# Altium schematic sheet INTERFACES.SchDoc
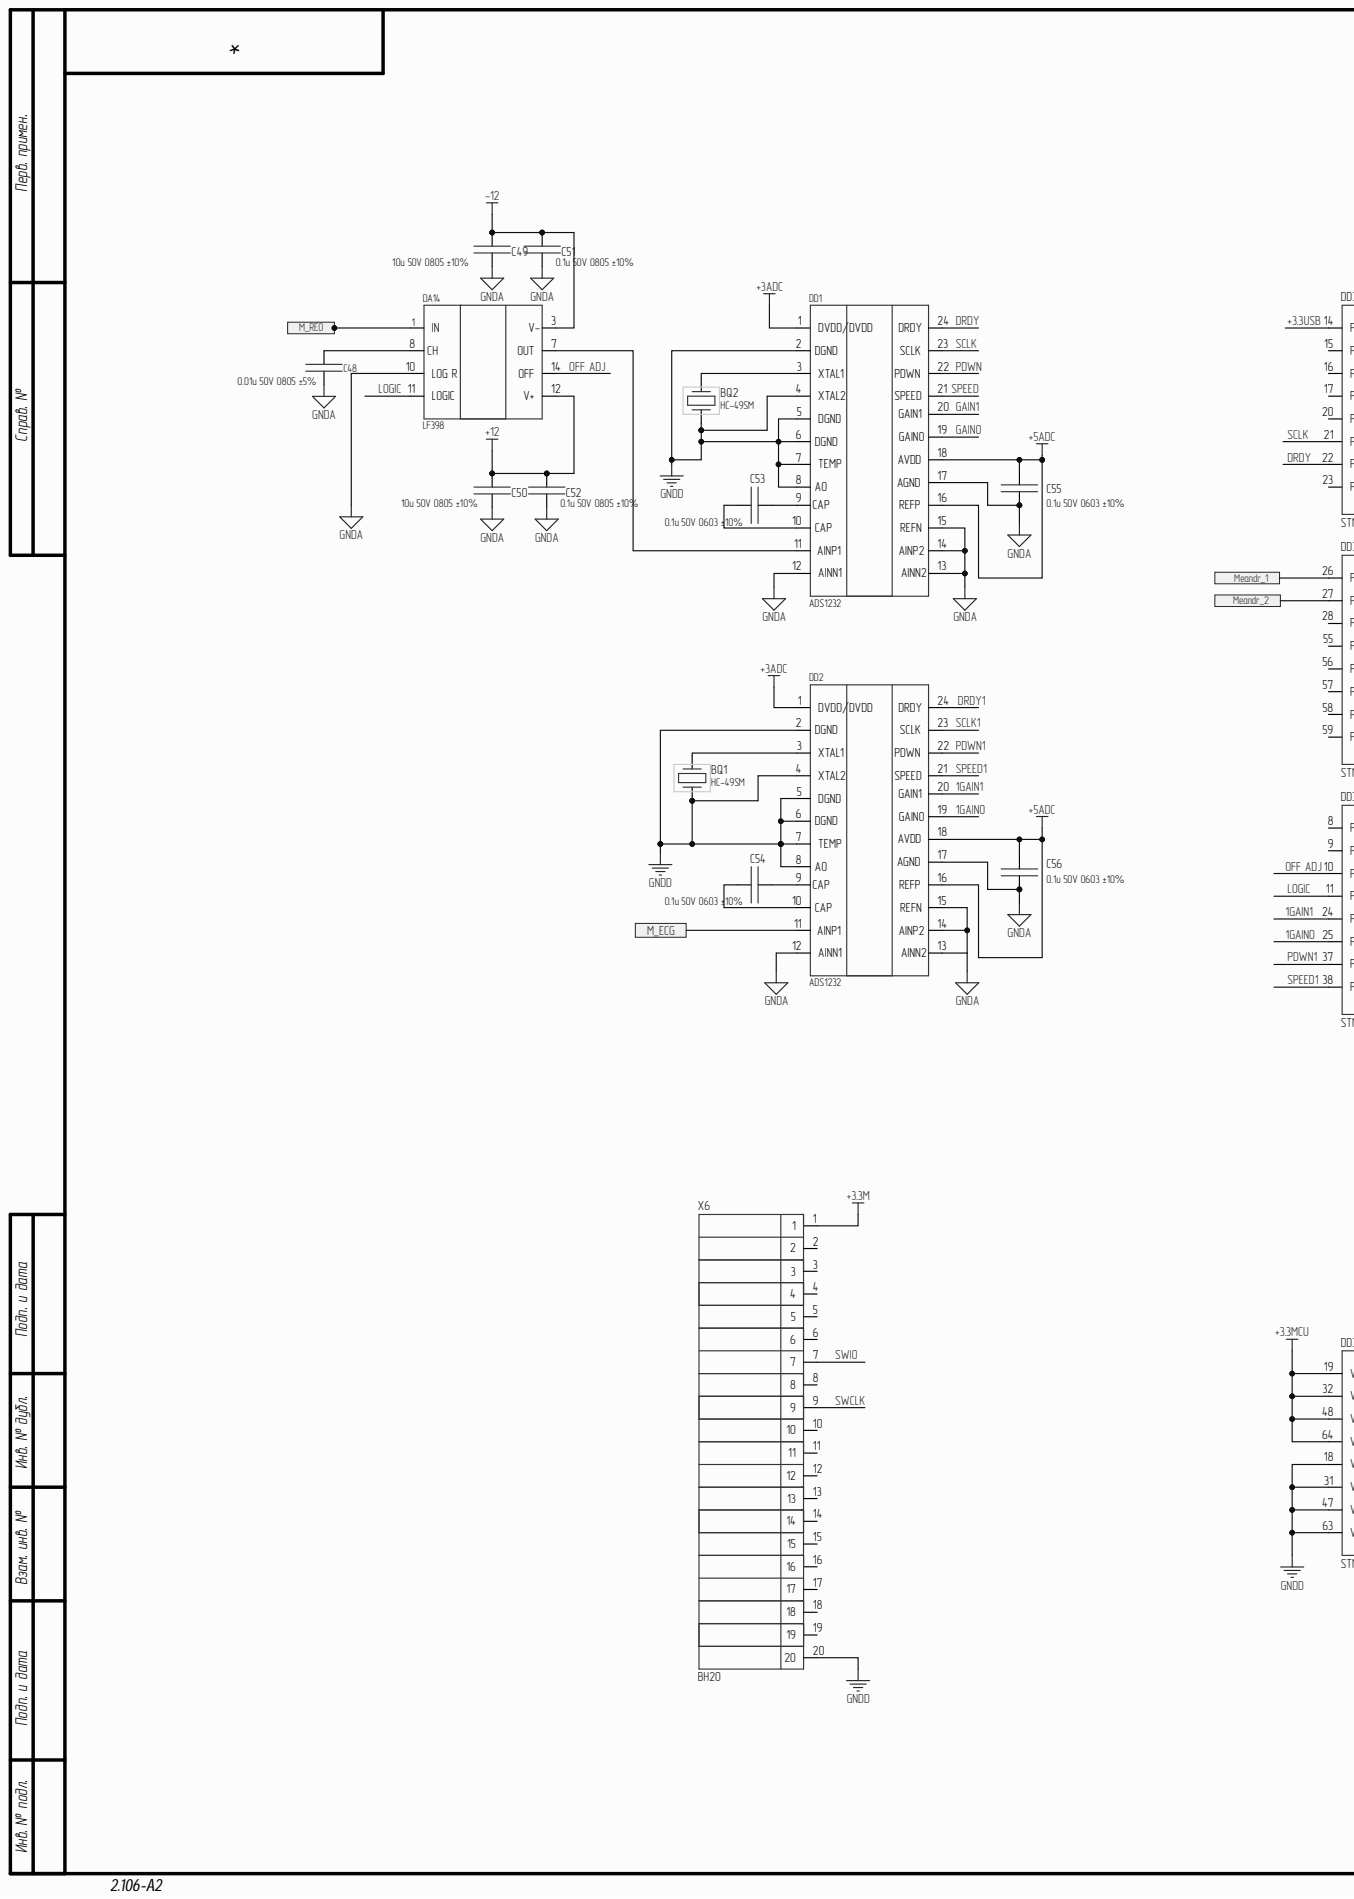
[diagram p0: INTERFACES.SchDoc, left]
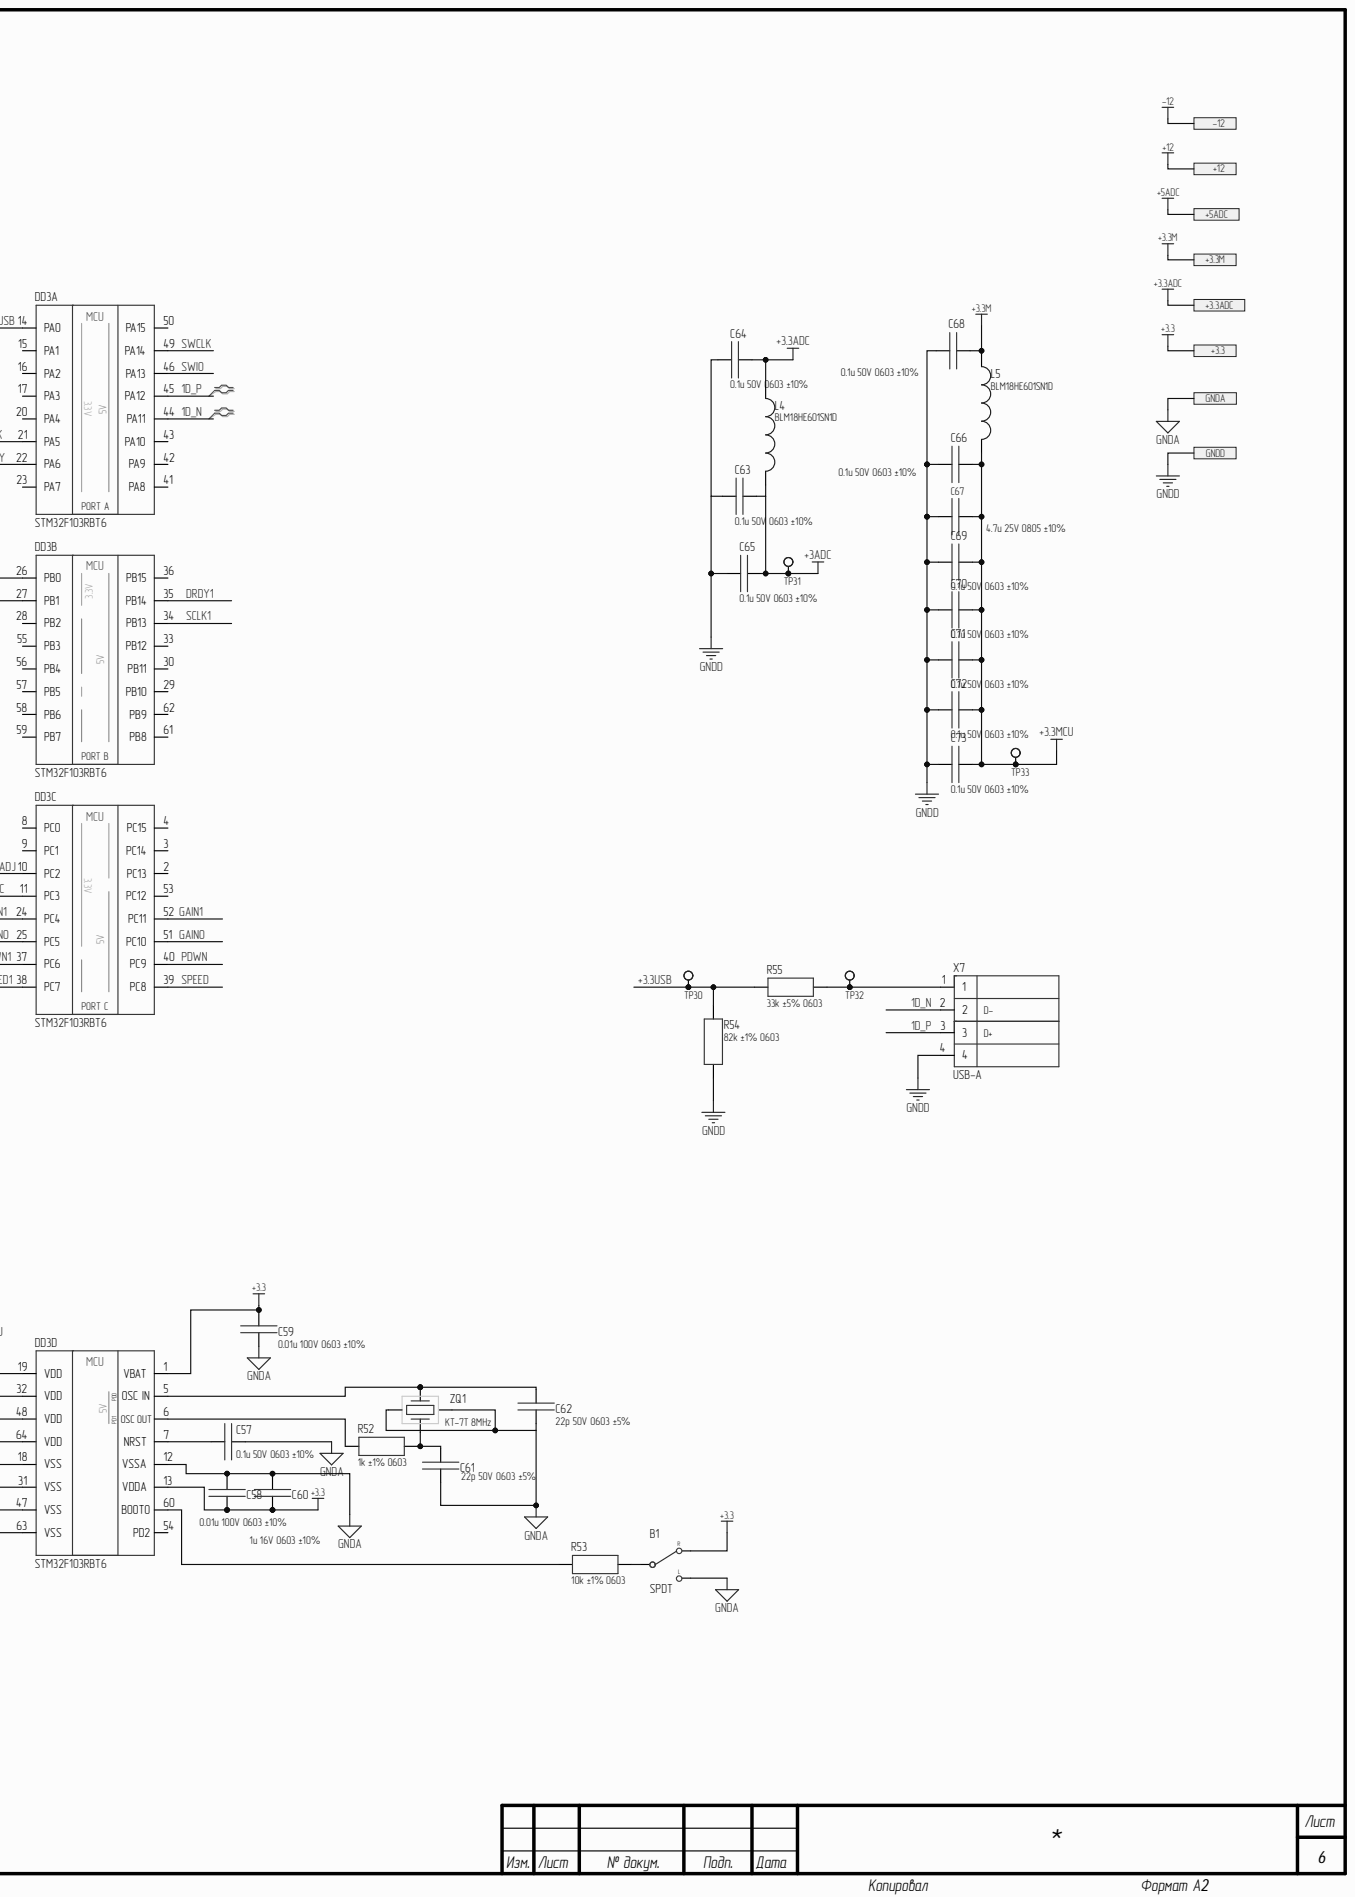
[diagram p1: INTERFACES.SchDoc, right]

## Parts
B1  SPDT  [Slide Switch 3 pin]
BQ1  HC-49SM  [CRYSTAL: HC-49SM]
BQ2  HC-49SM  [CRYSTAL: HC-49SM]
C48  0.01u 50V 0805 ±5%  [CAP: SMD 0805 MD IPC 782]
C49  10u 50V 0805 ±10%  [CAP: SMD 0805 MD IPC 782]
C50  10u 50V 0805 ±10%  [CAP: SMD 0805 MD IPC 782]
C51  0.1u 50V 0805 ±10%  [CAP: SMD 0805 MD IPC 782]
C52  0.1u 50V 0805 ±10%  [CAP: SMD 0805 MD IPC 782]
C53  0.1u 50V 0603 ±10%  [CAP: SMD 0603 MD IPC 782]
C54  0.1u 50V 0603 ±10%  [CAP: SMD 0603 MD IPC 782]
C55  0.1u 50V 0603 ±10%  [CAP: SMD 0603 MD IPC 782]
C56  0.1u 50V 0603 ±10%  [CAP: SMD 0603 MD IPC 782]
C57  0.1u 50V 0603 ±10%  [CAP: SMD 0603 MD IPC 782]
C58  0.01u 100V 0603 ±10%  [CAP: SMD 0603 MD IPC 782]
C59  0.01u 100V 0603 ±10%  [CAP: SMD 0603 MD IPC 782]
C60  1u 16V 0603 ±10%  [CAP: SMD 0603 MD IPC 782]
C61  22p 50V 0603 ±5%  [CAP: SMD 0603 MD IPC 782]
C62  22p 50V 0603 ±5%  [CAP: SMD 0603 MD IPC 782]
C63  0.1u 50V 0603 ±10%  [CAP: SMD 0603 MD IPC 782]
C64  0.1u 50V 0603 ±10%  [CAP: SMD 0603 MD IPC 782]
C65  0.1u 50V 0603 ±10%  [CAP: SMD 0603 MD IPC 782]
C66  0.1u 50V 0603 ±10%  [CAP: SMD 0603 MD IPC 782]
C67  4.7u 25V 0805 ±10%  [CAP: SMD 0805 MD IPC 782]
C68  0.1u 50V 0603 ±10%  [CAP: SMD 0603 MD IPC 782]
C69  0.1u 50V 0603 ±10%  [CAP: SMD 0603 MD IPC 782]
C70  0.1u 50V 0603 ±10%  [CAP: SMD 0603 MD IPC 782]
C71  0.1u 50V 0603 ±10%  [CAP: SMD 0603 MD IPC 782]
C72  0.1u 50V 0603 ±10%  [CAP: SMD 0603 MD IPC 782]
C73  0.1u 50V 0603 ±10%  [CAP: SMD 0603 MD IPC 782]
DA14  LF398  [IC: SOIC 14]
DD1  ADS1232  [TSSOP-24]
DD2  ADS1232  [TSSOP-24]
DD3  STM32F103RBT6  [MC: LQFP-64]  (parts A,B,C,D)
L4  BLM18HE601SN1D  [IND: SMD 0603 MD IPC 782]
L5  BLM18HE601SN1D  [IND: SMD 0603 MD IPC 782]
R52  1k ±1% 0603  [RES: SMD 0603 MD IPC 782]
R53  10k ±1% 0603  [RES: SMD 0603 MD IPC 782]
R54  82k ±1% 0603  [RES: SMD 0603 MD IPC 782]
R55  33k ±5% 0603  [RES: SMD 0603 MD IPC 782]
TP30  Test point  [Test Point]  (value not drawn)  (net tie)
TP31  Test point  [Test Point]  (value not drawn)  (net tie)
TP32  Test point  [Test Point]  (value not drawn)  (net tie)
TP33  Test point  [Test Point]  (value not drawn)  (net tie)
X6  BH20  [CON: BH-20]
X7  USB-A  [CON: 4PIN USB B]
ZQ1  KT-7T 8MHz  [CRYSTAL: 3225 4pin]

## Ports
+3.3, +3.3ADC, +3.3M, +5ADC, +12, -12, GNDA, GNDD, M_ECG, M_REO, Meandr_1, Meandr_2

## Nets
+3.3MCU: C66.1 C67.1 C69.2 C70.2 C71.2 C72.2 C73.2 DD3.19(VDD) DD3.32(VDD) DD3.48(VDD) DD3.64(VDD) L5.2 TP33.1
+3ADC: C63.1 C65.2 DD1.1(DVDD/DVDD) DD2.1(DVDD/DVDD) L4.2 TP31.1
1D_N: DD3.44(PA11) X7.2
1D_P: DD3.45(PA12) X7.3
1GAIN0: DD2.19(GAIN0) DD3.25(PC5)
1GAIN1: DD2.20(GAIN1) DD3.24(PC4)
+3.3: B1.3 C58.2 C59.1 C60.2 DD3.1(VBAT) DD3.13(VDDA) ; port +3.3
+3.3ADC: C64.2 L4.1 ; port +3.3ADC
+3.3M: C68.2 L5.1 X6.1 ; port +3.3M
+3.3USB: DD3.14(PA0) R54.2 R55.1 TP30.1
+5ADC: C55.1 C56.1 DD1.16(REFP) DD1.18(AVDD) DD2.16(REFP) DD2.18(AVDD) ; port +5ADC
+12: C50.1 C52.1 DA14.12(V+) ; port +12
-12: C49.1 C51.1 DA14.3(V-) ; port -12
DRDY: DD1.24(DRDY) DD3.22(PA6)
DRDY1: DD2.24(DRDY) DD3.35(PB14)
GAIN0: DD1.19(GAIN0) DD3.51(PC10)
GAIN1: DD1.20(GAIN1) DD3.52(PC11)
GNDA: B1.1 C48.2 C49.2 C50.2 C51.2 C52.2 C55.2 C56.2 C57.1 C58.1 C59.2 C60.1 C61.2 C62.2 DA14.10(LOG_R) DD1.12(AINN1) DD1.13(AINN2) DD1.14(AINP2) DD1.15(REFN) DD1.17(AGND) DD2.12(AINN1) DD2.13(AINN2) DD2.14(AINP2) DD2.15(REFN) DD2.17(AGND) DD3.12(VSSA) ZQ1.2 ZQ1.4 ; port GNDA
GNDD: BQ1.1 BQ2.1 C63.2 C64.1 C65.1 C66.2 C67.2 C68.1 C69.1 C70.1 C71.1 C72.1 C73.1 DD1.2(DGND) DD1.4(XTAL2) DD2.2(DGND) DD2.4(XTAL2) DD2.5(DGND) DD2.6(DGND) DD2.7(TEMP) DD2.8(A0) DD3.18(VSS) DD3.31(VSS) DD3.47(VSS) DD3.63(VSS) R54.1 X6.20 X7.4 ; port GNDD
LOGIC: DA14.11(LOGIC) DD3.11(PC3)
M_ECG: DD2.11(AINP1) ; port M_ECG
M_REO: DA14.1(IN) ; port M_REO
Meandr_1: DD3.26(PB0) ; port Meandr_1
Meandr_2: DD3.27(PB1) ; port Meandr_2
OFF ADJ: DA14.14(OFF) DD3.10(PC2)
PDWN: DD1.22(PDWN) DD3.40(PC9)
PDWN1: DD2.22(PDWN) DD3.37(PC6)
SCLK: DD1.23(SCLK) DD3.21(PA5)
SCLK1: DD2.23(SCLK) DD3.34(PB13)
SPEED: DD1.21(SPEED) DD3.39(PC8)
SPEED1: DD2.21(SPEED) DD3.38(PC7)
SWCLK: DD3.49(PA14) X6.9
SWIO: DD3.46(PA13) X6.7
NetB1_2: B1.2 R53.2
NetBQ1_2: BQ1.2 DD2.3(XTAL1)
NetBQ2_2: BQ2.2 DD1.3(XTAL1)
NetC48_1: C48.1 DA14.8(CH)
NetC53_1: C53.1 DD1.9(CAP)
NetC53_2: C53.2 DD1.10(CAP)
NetC54_1: C54.1 DD2.9(CAP)
NetC54_2: C54.2 DD2.10(CAP)
NetC57_2: C57.2 DD3.7(NRST)
NetC61_1: C61.1 R52.2 ZQ1.1
NetC62_1: C62.1 DD3.5(OSC_IN) ZQ1.3
NetDA14_7: DA14.7(OUT) DD1.11(AINP1)
NetDD1_5: DD1.5(DGND) DD1.6(DGND) DD1.7(TEMP) DD1.8(A0)
NetDD3_2: DD3.2(PC13)
NetDD3_3: DD3.3(PC14)
NetDD3_4: DD3.4(PC15)
NetDD3_6: DD3.6(OSC_OUT) R52.1
NetDD3_8: DD3.8(PC0)
NetDD3_9: DD3.9(PC1)
NetDD3_15: DD3.15(PA1)
NetDD3_16: DD3.16(PA2)
NetDD3_17: DD3.17(PA3)
NetDD3_20: DD3.20(PA4)
NetDD3_23: DD3.23(PA7)
NetDD3_28: DD3.28(PB2)
NetDD3_29: DD3.29(PB10)
NetDD3_30: DD3.30(PB11)
NetDD3_33: DD3.33(PB12)
NetDD3_36: DD3.36(PB15)
NetDD3_41: DD3.41(PA8)
NetDD3_42: DD3.42(PA9)
NetDD3_43: DD3.43(PA10)
NetDD3_50: DD3.50(PA15)
NetDD3_53: DD3.53(PC12)
NetDD3_54: DD3.54(PD2)
NetDD3_55: DD3.55(PB3)
NetDD3_56: DD3.56(PB4)
NetDD3_57: DD3.57(PB5)
NetDD3_58: DD3.58(PB6)
NetDD3_59: DD3.59(PB7)
NetDD3_60: DD3.60(BOOT0) R53.1
NetDD3_61: DD3.61(PB8)
NetDD3_62: DD3.62(PB9)
NetR55_2: R55.2 TP32.1 X7.1
NetX6_2: X6.2
NetX6_3: X6.3
NetX6_4: X6.4
NetX6_5: X6.5
NetX6_6: X6.6
NetX6_8: X6.8
NetX6_10: X6.10
NetX6_11: X6.11
NetX6_12: X6.12
NetX6_13: X6.13
NetX6_14: X6.14
NetX6_15: X6.15
NetX6_16: X6.16
NetX6_17: X6.17
NetX6_18: X6.18
NetX6_19: X6.19

## Library data of the parts
B1 = Slide switch SPDT: Code: -; Component Kind: Standard; Name: -; Library Name: UOMP mechanical.SchLib
BQ1 BQ2 = SMD 4.9152MHz 2pin 10.3x4.5 16pF_1: Code: 6321974907; Name: Резонатор кварцевый HC49SM 7.3728 MHz; Parameter 1: 7.3728 MHz; Component Kind: Standard; Library Name: UOMP_crystals.SchLib
C48 = 0.01u 50V 0805 ±5%: Code: 6279261451; Name: Конденсатор X7R 50V  0,01uF±5%  0805; Component Kind: Standard; Library Name: UOMP_capacitors.SchLib
C49 C50 = 10u 50V 0805 ±10%: Code: 6289735441; Name: Конденсатор 12065D106KAT2A, AVX; Component Kind: Standard; Library Name: UOMP_capacitors.SchLib
C51 C52 = 0.1u 50V 0805 ±10%: Code: 6279254871; Name: Конденсатор X7R 50V 0,1uF ±10% 0805; Component Kind: Standard; Library Name: UOMP_capacitors.SchLib
C53-C57 C63-C66 C68-C73 = 0.1u 50V 0603 ±10%: CC0603KRX7R9BB104; Code: 6279259871; Name: Конденсатор X7R 50V 0,1uF +-10% 0603; Component Kind: Standard
  C53-C57: Library Name: UOMP_capacitors.SchLib
  C63-C66 C68-C73: Library Name: UOMP capacitors.SchLib
C58 C59 = 0.01u 100V 0603 ±10%: Code: 6270003321; Name: Конденсатор СС0603KRX7R0BB104, Yageo; Component Kind: Standard; Library Name: UOMP_capacitors.SchLib
C60 = 1u 16V 0603 ±10%: Code: 62193105441; Name: Конденсатор 0603-NPO-25В-1000; Component Kind: Standard; Library Name: UOMP_capacitors.SchLib
C61 C62 = 22p 50V 0603 ±5%: Code: 6219310401; Name: Конденсатор 0603CG220J500NT, Fenghua; Component Kind: Standard; Library Name: UOMP capacitors.SchLib
C67 = 4.7u 25V 0805 ±10%: Code: 6270000501; Name: Конденсатор X7R 25V 4,7uF±10% 0805; Component Kind: Standard; Library Name: UOMP_capacitors.SchLib
DA14 = LF398MX: Component Kind: Standard; Library Name: THE_BOYS_REO.SchLib
DD3 = STM32F103 lqfp64 64kb: Code: 6335530806; Name: Микросхема STM32F103RBT6; Component Kind: Standard; Library Name: UOMP_microcontrollers.SchLib
L4 L5 = 600Ohm 0,8A: Code: 6310011671; Name: Дроссель BLM18HE601SN1D, Murata; Component Kind: Standard; Library Name: UOMP_inductors.SchLib
R52 = 1k ±1% 0603: Code: 6069174188; Name: Резистор 1k ±1% 0603; Component Kind: Standard; Library Name: UOMP resistors.SchLib
R53 = 10k ±1% 0603: Code: 6069191961; Name: Резистор 10K+-1% TK50 0603; Component Kind: Standard; Library Name: UOMP resistors.SchLib
R54 = 82k ±1% 0603: Code: 6060002261; Name: Резистор 82K±1% 0603; Component Kind: Standard; Library Name: UOMP_resistors.SchLib
R55 = 33k ±5% 0603: Code: 6069179211; Name: Резистор 33k ±5% 0603; Component Kind: Standard; Library Name: UOMP_resistors.SchLib
X6 = 20 pin IDC BH20 2.54: Code: 6313922720; Name: Вилка BH-20 Импорт; Component Kind: Standard; Library Name: UOMP_connectors.SchLib
X7 = 4 pin USB: Component Kind: Standard; Library Name: UOMP_connectors.SchLib
ZQ1 = SMD 8MHz 4pin 3.2x2.5 22pF: Code: 6329545441; Name: Резонатор кварцевый KT-7X 8.0 MHz; Component Kind: Standard; Library Name: UOMP crystals.SchLib
DD1 DD2 = ADS1232: Component Kind: Standard; Library Name: THE_BOYS_REO.SchLib
TP30-TP33 = Test point: Library Name: UOMP_primitives.SchLib
